# Altium schematic sheet p05_leds.SchDoc
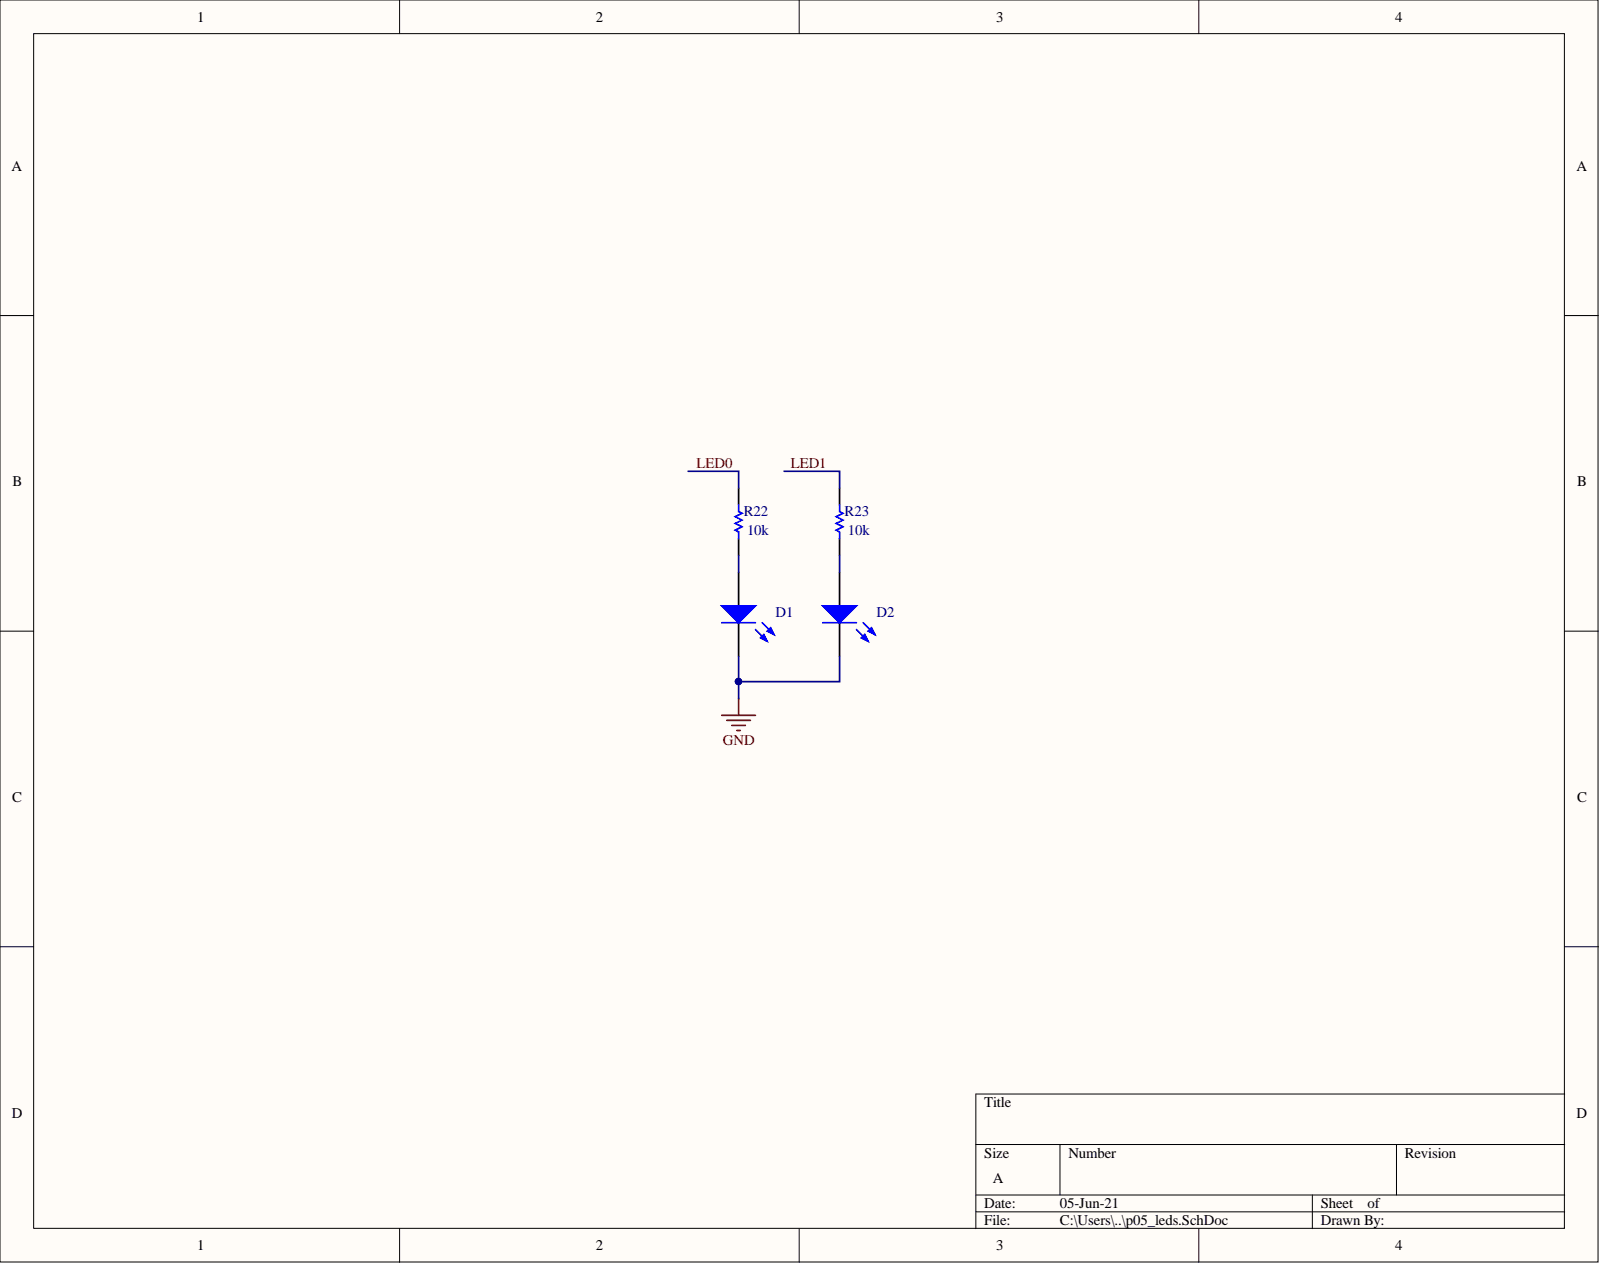
[diagram p0: p05_leds.SchDoc]

## Parts
D1  LED0  [6-0805_M]  (value not drawn)
D2  LED0  [6-0805_M]  (value not drawn)
R22  value 10k  [6-0805_M]  (hidden comment: Res1)
R23  value 10k  [6-0805_M]  (hidden comment: Res1)

## Nets
GND: D1.2 D2.2
LED0: R22.1
LED1: R23.1
NetD1_1: D1.1 R22.2
NetD2_1: D2.1 R23.2

## Library data of the parts
D1 D2 = LED0: Typical INFRARED GaAs LED; Component Kind: Standard; LatestRevisionDate: 08-Jul-2005; LatestRevisionNote: Mech Layer 1 removed.; Library Name: Miscellaneous Devices.IntLib; PackageDescription: LED; 2 Leads; PackageReference: LED-0; Published: 8-Jun-2000; Simulation: LED0; Publisher: Altium Limited
R22 R23 = Res1: Resistor; Component Kind: Standard; LatestRevisionDate: 17-Jul-2002; LatestRevisionNote: Re-released for DXP Platform.; Library Name: Miscellaneous Devices.IntLib; PackageDescription: Axial Device, Thru-Hole; 2 Leads; 0.3 in Pin Spacing; PackageReference: AXIAL-0.3; Published: 8-Jun-2000; Signal Integrity: Res; Simulation: RESISTOR; Publisher: Altium Limited
